# Altium schematic sheet HV_Circuits.SchDoc(HV_Circuits)
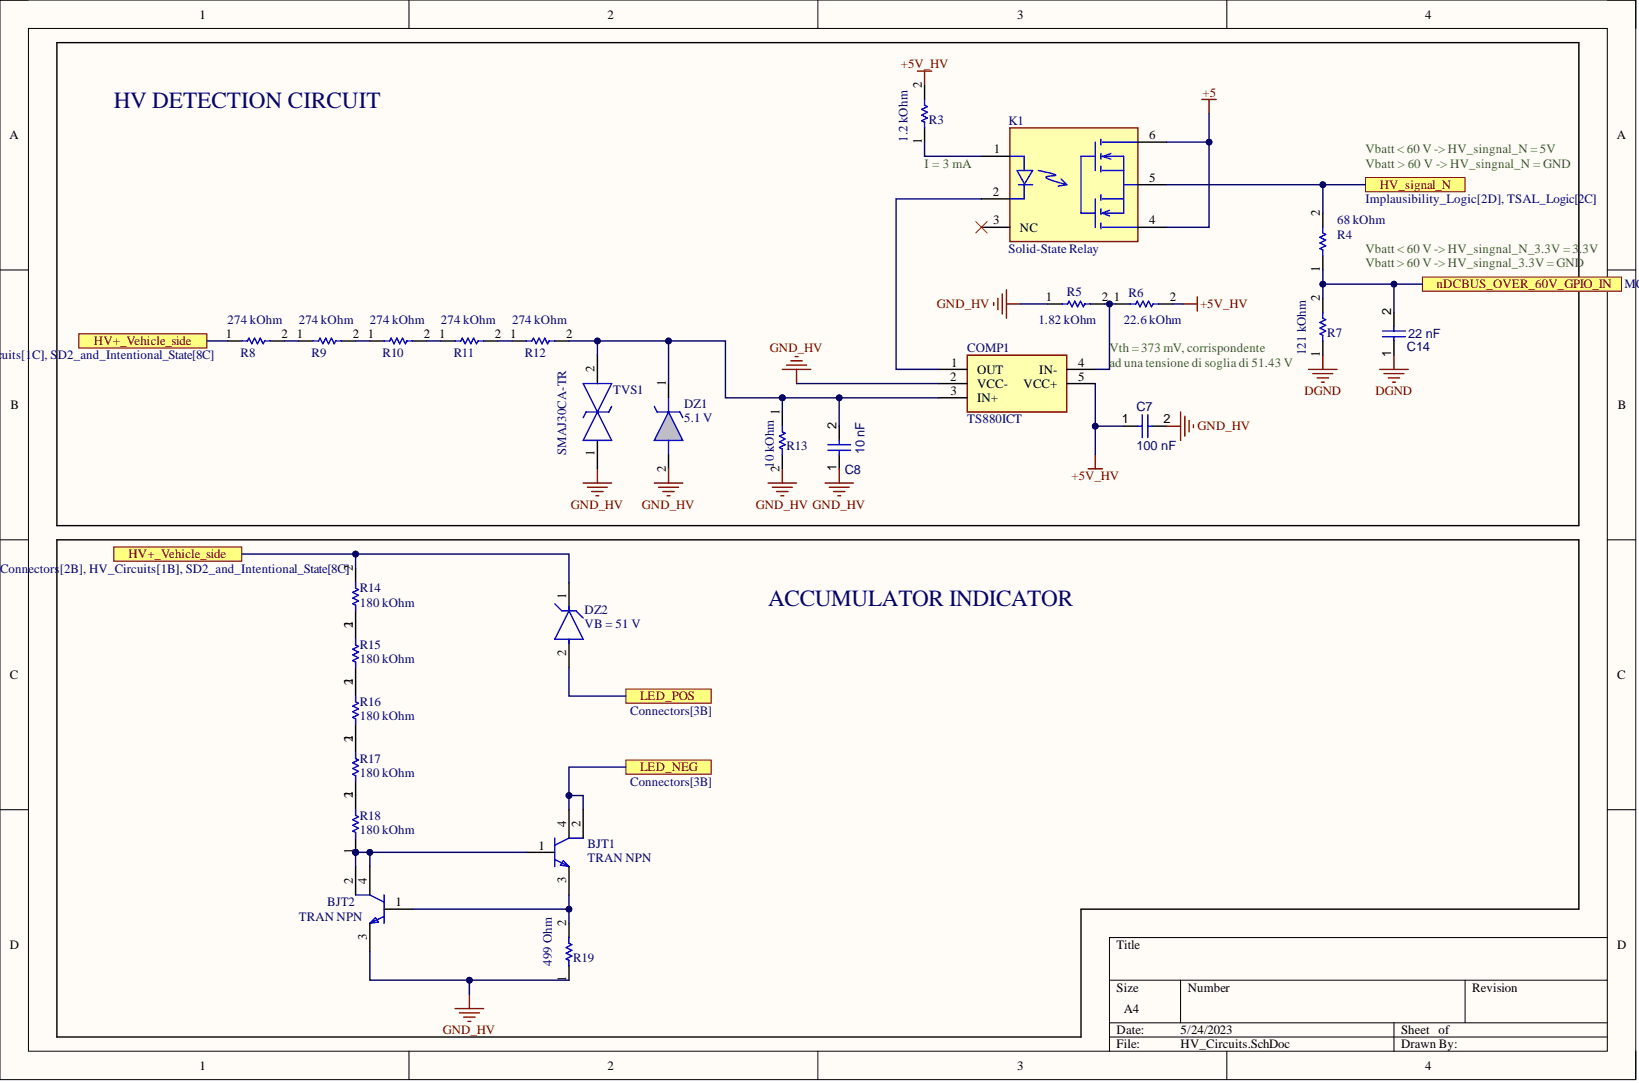
[diagram p0: HV_Circuits.SchDoc]

## Parts
BJT1  TRAN NPN
BJT2  TRAN NPN  Polarity: NPN
C7  100 nF
C8  10 nF
C14  22 nF
COMP1  TS880ICT
DZ1  5.1 V
DZ2  VB = 51 V
K1  Solid-State Relay  Category: Relay
R3  1.2 kOhm
R4  68 kOhm
R5  1.82 kOhm
R6  22.6 kOhm
R7  121 kOhm
R8  274 kOhm
R9  274 kOhm
R10  274 kOhm
R11  274 kOhm
R12  274 kOhm
R13  10 kOhm
R14  180 kOhm
R15  180 kOhm
R16  180 kOhm
R17  180 kOhm
R18  180 kOhm
R19  499 Ohm
TVS1  SMAJ30CA-TR

## Ports
HV+_Vehicle_side, HV_signal_N, LED_NEG, LED_POS, nDCBUS_OVER_60V_GPIO_IN

## Nets
+5: K1.4 K1.6
+5V_HV: C7.1 COMP1.5(VCC+) R3.2 R6.2
DGND: C14.1 R7.1
GND_HV: BJT2.3 C7.2 C8.1 COMP1.2(VCC-) DZ1.2 R5.1 R13.2 R19.1 TVS1.1
HV+_Vehicle_side: DZ2.1 R8.1 R14.2 ; port HV+_Vehicle_side
HV_signal_N: K1.5 R4.2 ; port HV_signal_N
LED_NEG: BJT1.2 BJT1.4 ; port LED_NEG
LED_POS: DZ2.2 ; port LED_POS
nDCBUS_OVER_60V_GPIO_IN: C14.2 R4.1 R7.2 ; port nDCBUS_OVER_60V_GPIO_IN
NetBJT1_1: BJT1.1 BJT2.2 BJT2.4 R18.1
NetBJT1_3: BJT1.3 BJT2.1 R19.2
NetC8_2: C8.2 COMP1.3(IN+) DZ1.1 R12.2 R13.1 TVS1.2
NetCOMP1_1: COMP1.1(OUT) K1.2
NetCOMP1_4: COMP1.4(IN-) R5.2 R6.1
NetK1_1: K1.1 R3.1
NetR8_2: R8.2 R9.1
NetR9_2: R9.2 R10.1
NetR10_2: R10.2 R11.1
NetR11_2: R11.2 R12.1
NetR14_1: R14.1 R15.2
NetR15_1: R15.1 R16.2
NetR16_1: R16.1 R17.2
NetR17_1: R17.1 R18.2

Not connected: K1 3(NC)

## Library data of the parts
BJT1 BJT2 = CMP-12195-000013-1: STN0214; Collector Emitter Breakdown Voltage: 1.2kV; Collector Emitter Saturation Voltage: 300mV; Continuous Collector Current: 200mA; Element Configuration: Single; Emitter Base Voltage (VEBO): 6V; hFE Min: 3; Max Power Dissipation: 1.6W; Number of Elements: 1; Tech: SMD; Case/Package: SOT-223; Collector Emitter Voltage (VCEO): 1.2kV; Collector-emitter Voltage-Max: 300mV; Component Kind: Standard; ComponentLink1Description: Website; ComponentLink1URL: https://www.mouser.it/ProductDetail/STMicroelectronics/STN0214?qs=pmcUWs1B1IKOCzUPe9wAgg%3D%3D; Lead Free: Lead Free; Library Name: Altium Content Vault; Max Breakdown Voltage: 1.2kV; Max Collector Current: 200mA; Max Operating Temperature: 150°C; Min Operating Temperature: -65°C; Mount: Surface Mount; Number of Pins: 4; Package Quantity: 1; Packaging: Tape and Reel; Power Dissipation: 1.6W; Radiation Hardening: No; REACH SVHC: No SVHC; RoHS Compliant: Yes; Series: -
  BJT1: Polarity: NPN
C7 = CMP-001-00085-2: VJ0805Y104MXATW1BC; Tech: SMD; Component Kind: Standard; ComponentLink1Description: Website; Package: 0805; Library Name: EMEA- Squadra Corse PoliTO-  Politecnico di Torino
C8 = CMP-001-00085-2: VJ0805H103KXBBC31; Tech: SMD; Component Kind: Standard; ComponentLink1Description: Website; Package: 0805; Library Name: EMEA- Squadra Corse PoliTO-  Politecnico di Torino
C14 = CMP-001-00085-2: VJ0805Y223JXACW1BC; Tech: SMD; Component Kind: Standard; ComponentLink1Description: Website; ComponentLink1URL: https://www.mouser.it/ProductDetail/Vishay-Vitramon/VJ0805Y223JXXPW1BC?qs=dYENeDlLSFWEZ4cdRF5phA%3D%3D; Package: 0805; Library Name: EMEA- Squadra Corse PoliTO-  Politecnico di Torino
COMP1 = CMP-030-000037-3: Rail-to-rail 0.9V nanopower; Tech: SMD; Component Kind: Standard; Package: SC-70-5; Library Name: EMEA- Squadra Corse PoliTO-  Politecnico di Torino
DZ1 = CMP-002-000008-1: MMSZ5231C-E3-08; Manufacturer_Name: Vishay; Geometry.Height: 1.35mm; Tech: SMD; Component Kind: Standard; ComponentLink1Description: Website; ComponentLink1URL: https://www.mouser.it/ProductDetail/Vishay-Semiconductors/MMSZ5231C-E3-08?qs=S660mHfF5o35EDHKMfkp8w%3D%3D; Datasheet Link: https://www.arrow.com/en/products/mmsz5231c-e3-08/vishay; Design Item ID: MMSZ5231C-E3-08; Manufacturer_Part_Number: MMSZ5231C-E3-08; Mouser Part Number: 78-MMSZ5231C-E3-08; Mouser Price/Stock: https://www.mouser.co.uk/ProductDetail/Vishay-Semiconductors/MMSZ5231C-E3-08?qs=S660mHfF5o35EDHKMfkp8w%3D%3D; Package: SOD-123-2; Source: MMSZ5231C-E3-08.SchLib; Library Name: EMEA- Squadra Corse PoliTO-  Politecnico di Torino
DZ2 = CMP-56208-000009-1: SMAZ5942B-E3/61; Element Configuration: Single; Impedance: 5.5R; Max Power Dissipation: 1.5W; Max Reverse Leakage Current: 500nA; Test Current: 34.1mA; Tolerance: 5%; Voltage Rating (DC): 11V; Zener Voltage: 51V; Case/Package: DO-214AC; Component Kind: Standard; ComponentLink1Description: Website; ComponentLink1URL: https://www.mouser.it/ProductDetail/Vishay-General-Semiconductor/SMAZ5942B-E3-61?qs=SH0Geuu7vjcUsnhckh9I6Q%3D%3D; Lead Free: Lead Free; Library Name: Altium Content Vault; Max Operating Temperature: 150°C; Min Operating Temperature: -65°C; Mount: Surface Mount; Number of Pins: 2; Package Quantity: 1; Packaging: Tape and Reel; Power Dissipation: 1.5W; Power Rating: 1.5W; RoHS Compliant: Yes; Voltage Tolerance: 5%
K1 = CMP-2000-07398-2: VO14642AABTR; Manufacturer: Vishay; Input Current: 50mA; Isolation Voltage: 5300Vrms; Max Junction Temp: +125°C; Max Power Dissipation: 330mW; Number Of Contacts: 1; On State Resistance: 0.25Ω; Output Current: 2A; Output Voltage: 60V; Packag: SMD DIP-6; Standoff Height: 0.05mm; Tech: SMD; Throw Configuration: SPST-NO; Ambient Temperature Range High: +85°C; Ambient Temperature Range Low: -55°C; Automotive: No; Component Kind: Standard; ComponentLink2Description: Datasheet; ComponentLink2URL: http://www.vishay.com/docs/81646/vo14642a.pdf; ComponentLink3Description: Website; ComponentLink3URL: https://www.mouser.it/ProductDetail/Vishay-Semiconductors/VO14642AABTR?qs=%2Fjqivxn91cdjDkQHAFlOvw%3D%3D; Device Class L1: Electromechanical; Device Class L2: Relays; Device Class L3: Solid State Relays; Digikey Description: SSR RELAY SPST-NO 2A 0-60V; Height: 4.25mm; Ipc Land Pattern Name: SOIC254P980X425-6; Lead Free: Yes; Library Name: Altium Content Vault; Manufacturer Part Number: VO14642AABTR; Mouser Description: Solid State Relays - PCB Mount Normally Open Form 1A 60V; Octopart: https://octopart.com/vo14642aabtr-vishay-42763680; Package: VO14642AABTR; RoHS: TRUE; Temperature: to +125°C; Temperature Range High: +125°C
R3 = CMP-009-00179-4: CRCW06031K20FKEAHP; Tech: SMD; Component Kind: Standard; ComponentLink1Description: Website; ComponentLink1URL: https://www.mouser.it/ProductDetail/Vishay-Dale/CRCW06031K20FKEAHP?qs=40TFAHZMFveP9B2Fb67Ndg%3D%3D; Package: 0603; Library Name: EMEA- Squadra Corse PoliTO-  Politecnico di Torino
R4 = CMP-009-00179-4: CRCW060368K0FKEAC; Tech: SMD; Component Kind: Standard; ComponentLink1Description: Website; ComponentLink1URL: https://www.mouser.it/ProductDetail/Vishay-Dale/CRCW060368K0FKEAC?qs=E3Y5ESvWgWOWm3dIamBggg%3D%3D; Package: 0603; Library Name: EMEA- Squadra Corse PoliTO-  Politecnico di Torino
R5 = CMP-009-00179-4: TNPW06031K82BEEA; Tech: SMD; Component Kind: Standard; ComponentLink1Description: Website; ComponentLink1URL: https://www.mouser.it/ProductDetail/Vishay-Dale/TNPW06031K82BEEN?qs=B4lwOSfBLoK%2FNl1eufL6Ag%3D%3D; Package: 0603; Library Name: EMEA- Squadra Corse PoliTO-  Politecnico di Torino
R6 = CMP-009-00179-4: TNPW060322K6BEEA; Tech: SMD; Component Kind: Standard; ComponentLink1Description: Website; ComponentLink1URL: https://www.mouser.it/ProductDetail/Vishay-Dale/TNPW060322K6BEEA?qs=rhzOH%252BTaZWtuxy%2Fjrq7A9g%3D%3D; Package: 0603; Library Name: EMEA- Squadra Corse PoliTO-  Politecnico di Torino
R7 = CMP-009-00179-4: CRCW0603121KFKEA; Tech: SMD; Component Kind: Standard; ComponentLink1Description: Website; ComponentLink1URL: https://www.mouser.it/ProductDetail/Vishay-Dale/CRCW0603121KFKEA?qs=SNwsiWikH8rxaNKbUsRIDA%3D%3D; Package: 0603; Library Name: EMEA- Squadra Corse PoliTO-  Politecnico di Torino
R8-R12 = CMP-009-00181-1: TNPW1206274KBEEA; Tech: SMD; Component Kind: Standard; ComponentLink1Description: Website; ComponentLink1URL: https://www.mouser.it/ProductDetail/Vishay-Beyschlag/MCA12060D2743BP100?qs=hjSuqQECij%2F2NG89e2917g%3D%3D; Package: 1206; Library Name: EMEA- Squadra Corse PoliTO-  Politecnico di Torino
R13 = CMP-009-00179-4: CRCW060310K0FKEAHP; Tech: SMD; Component Kind: Standard; ComponentLink1Description: Website; ComponentLink1URL: https://www.mouser.it/ProductDetail/Vishay-Dale/TNPW060310K0BEEC?qs=FzhAKWsHbnBcHjtNFnpYzQ%3D%3D; Package: 0603; Library Name: EMEA- Squadra Corse PoliTO-  Politecnico di Torino
R14-R18 = CMP-009-00181-1: CRMA1206AF180KFKEF; Tech: SMD; Component Kind: Standard; ComponentLink1Description: Website; ComponentLink1URL: https://www.mouser.it/ProductDetail/Vishay/CRMA1206AF180KFKEF?qs=QNEnbhJQKvZoVL5AZkX8fA%3D%3D; Package: 1206; Library Name: EMEA- Squadra Corse PoliTO-  Politecnico di Torino
R19 = CMP-009-00179-4: CRCW0603499RFKEAHP; Tech: SMD; Component Kind: Standard; ComponentLink1Description: Website; ComponentLink1URL: https://www.mouser.it/ProductDetail/Vishay-Dale/CRCW0603499RFKEAHP?qs=k2%2FDWSARqgGdRUjwu2QzYw%3D%3D; Package: 0603; Library Name: EMEA- Squadra Corse PoliTO-  Politecnico di Torino
TVS1 = CMP-004-000005-1: TVS Diode Bi-Directional SMAJ30CA-TR 64.3V; Manufacturer_Name: STMicroelectronics; Arrow Part Number: SMAJ30CA-TR; Arrow Price/Stock: https://www.arrow.com/en/products/smaj30ca-tr/stmicroelectronics?region=nac; Component Kind: Standard; Datasheet Link: https://www.st.com/resource/en/datasheet/smaj10ca.pdf; Design Item ID: SMAJ30CA-TR; Height: 2.65mm; Manufacturer_Part_Number: SMAJ30CA-TR; Mouser Part Number: 511-SMAJ30CA-TR; Mouser Price/Stock: https://www.mouser.co.uk/ProductDetail/STMicroelectronics/SMAJ30CA-TR?qs=0TJjK1waviDY0EkLaKH12g%3D%3D; Source: SamacSys.SchLib; Library Name: EMEA- Squadra Corse PoliTO-  Politecnico di Torino
